# Altium schematic sheet PWM_Pump_Led.SchDoc
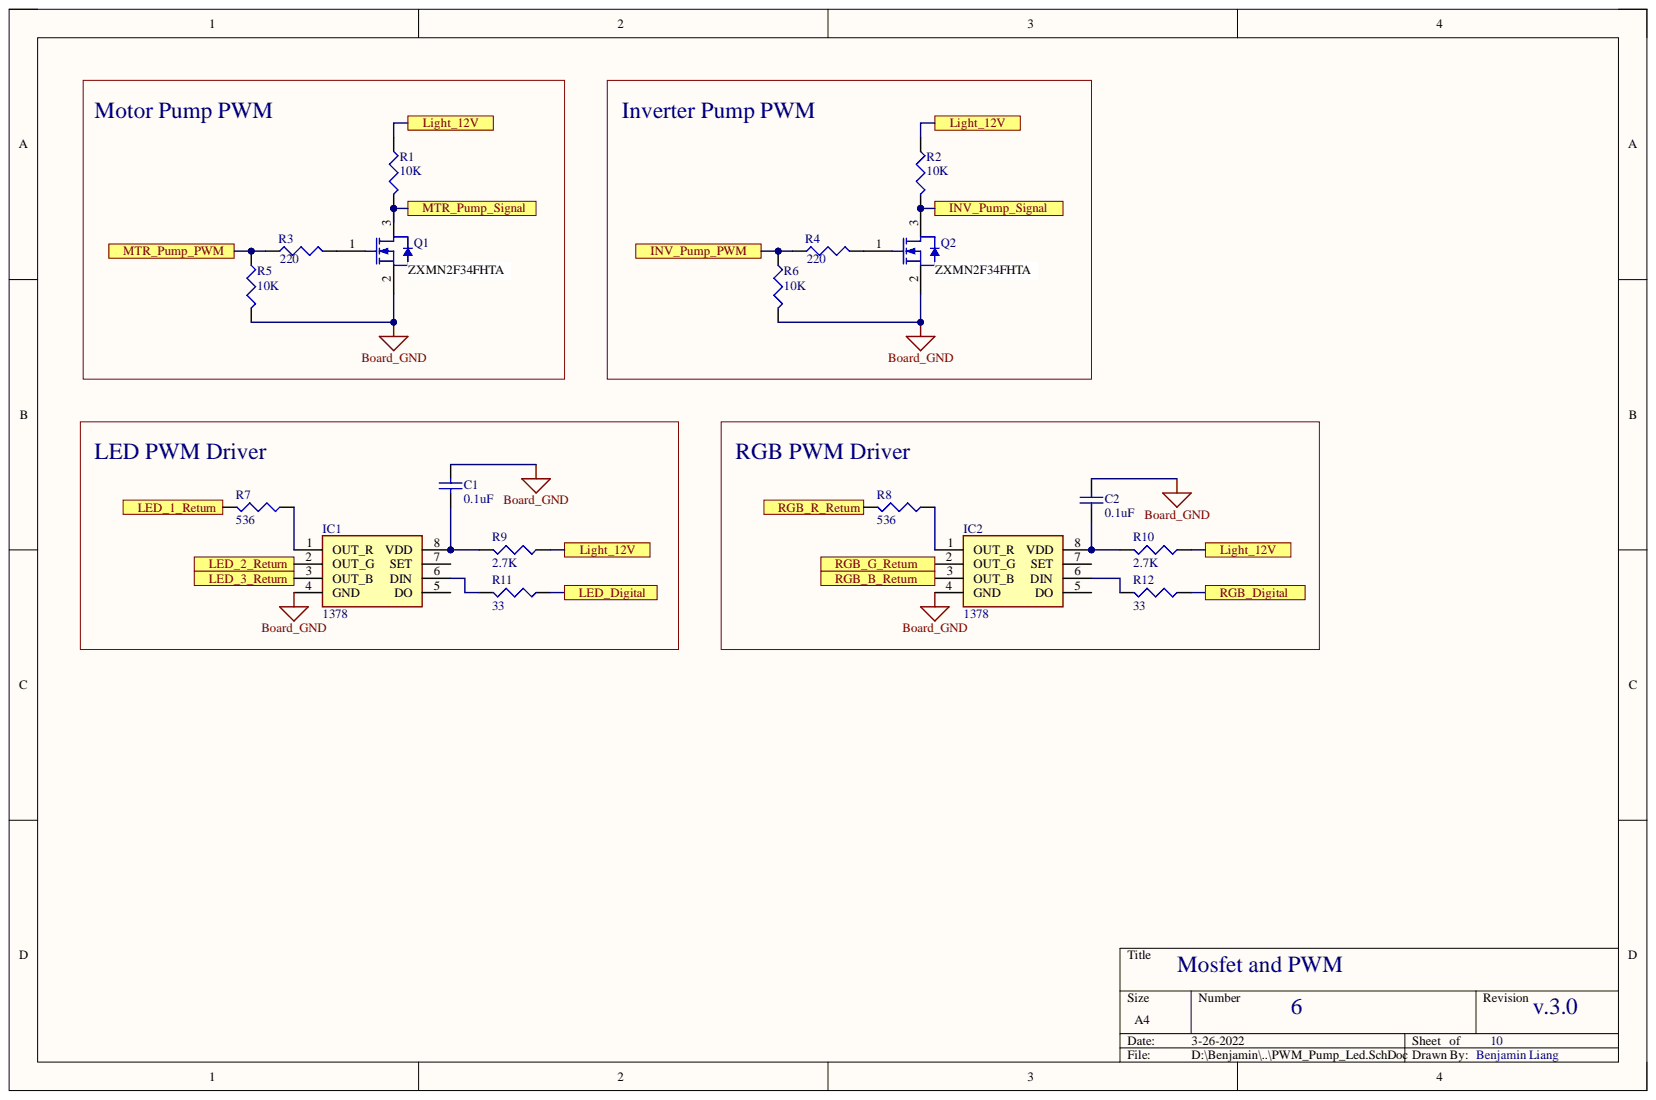
[diagram p0: PWM_Pump_Led.SchDoc]

## Parts
C1  0.1uF  [C1206]
C2  0.1uF  [C1206]
IC1  1378  [DIP762W51P254L920H431Q8N]
IC2  1378  [DIP762W51P254L920H431Q8N]
Q1  ZXMN2F34FHTA  [FAIR-SSOT3L-3_V]
Q2  ZXMN2F34FHTA  [FAIR-SSOT3L-3_V]
R1  10K  [J1-0603]
R2  10K  [J1-0603]
R3  220  [J1-0603]
R4  220  [J1-0603]
R5  10K  [J1-0603]
R6  10K  [J1-0603]
R7  536  [J1-0603]
R8  536  [J1-0603]
R9  2.7K  [J1-0603]
R10  2.7K  [J1-0603]
R11  33  [J1-0603]
R12  33  [J1-0603]

## Ports
INV_Pump_PWM, INV_Pump_Signal, LED_1_Return, LED_2_Return, LED_3_Return, LED_Digital, Light_12V, MTR_Pump_PWM, MTR_Pump_Signal, RGB_B_Return, RGB_Digital, RGB_G_Return, RGB_R_Return

## Nets
Board_GND: C1.1 C2.1 IC1.4(GND) IC2.4(GND) Q1.2 Q2.2 R5.2 R6.2
INV_Pump_PWM: R4.1 R6.1 ; port INV_Pump_PWM
INV_Pump_Signal: Q2.3 R2.2 ; port INV_Pump_Signal
LED_1_Return: R7.2 ; port LED_1_Return
LED_2_Return: IC1.2(OUT_G) ; port LED_2_Return
LED_3_Return: IC1.3(OUT_B) ; port LED_3_Return
LED_Digital: R11.1 ; port LED_Digital
Light_12V: R1.1 R2.1 R9.1 R10.1 ; port Light_12V
MTR_Pump_PWM: R3.1 R5.1 ; port MTR_Pump_PWM
MTR_Pump_Signal: Q1.3 R1.2 ; port MTR_Pump_Signal
RGB_B_Return: IC2.3(OUT_B) ; port RGB_B_Return
RGB_Digital: R12.1 ; port RGB_Digital
RGB_G_Return: IC2.2(OUT_G) ; port RGB_G_Return
RGB_R_Return: R8.2 ; port RGB_R_Return
NetC1_2: C1.2 IC1.8(VDD) R9.2
NetC2_2: C2.2 IC2.8(VDD) R10.2
NetIC1_1: IC1.1(OUT_R) R7.1
NetIC1_6: IC1.6(DIN) R11.2
NetIC2_1: IC2.1(OUT_R) R8.1
NetIC2_6: IC2.6(DIN) R12.2
NetQ1_1: Q1.1 R3.2
NetQ2_1: Q2.1 R4.2

Not connected: IC1 5(DO) 7(SET); IC2 5(DO) 7(SET)

## Library data of the parts
C1 C2 = Cap Semi: Capacitor (Semiconductor SIM Model); Value: 100nF; Component Kind: Standard; LatestRevisionDate: 29-May-2009; LatestRevisionNote: IPC-7351 Footprint Added.; Library Name: Miscellaneous Devices.IntLib; PackageDescription: Chip Capacitor; PackageReference: C1206; Published: 8-Jun-2000; Signal Integrity: Cap Semi; Simulation: CAP; Publisher: Altium Limited
IC1 IC2 = 1378: Integrated Circuit; Manufacturer_Name: Adafruit; Arrow Part Number: 1378; Arrow Price/Stock: https://www.arrow.com/en/products/1378/adafruit-industries; Component Kind: Standard; Datasheet Link: https://componentsearchengine.com/Datasheets/2/1378.pdf; Height: 4.31mm; Manufacturer_Part_Number: 1378; Mouser Part Number: 485-1378; Mouser Price/Stock: https://www.mouser.co.uk/ProductDetail/Adafruit/1378?qs=GURawfaeGuC97B4u3AEc0g%3D%3D
Q1 Q2 = CMP-2000-06249-1: Manufacturer: FairchildSemiconductor; Channel Mode: Enhancement; Channel Type: N; Maximum Continuous Drain Current: 1.7A; Maximum Drain Source Voltage: 20V; Maximum Gate Source Voltage: ±8V; Package Version: Rev. 3, 05/2015; Rad Hard: No; RDS-on: 0.07@4.5VOhm; Typical Fall Time: 3ns; Typical Rise Time: 8.5ns; Typical Turn-Off Delay Time: 11ns; Typical Turn-On Delay Time: 5ns; Category: MOSFET; Component Kind: Standard; Datasheet URL: https://www.fairchildsemi.com/datasheets/FD/FDN335N.pdf; Datasheet Version: Rev. C, 04/1999; Description: ZXMN2F34FHTA; Library Name: Altium Content Vault; Manufacturer URL: https://www.fairchildsemi.com/; Mounting: SurfaceMount; Mounting Technology: SurfaceMount; Operating Temperature: -55to150°C; Package: 3SuperSOT; Package Description: 3-Pin Small Outline Transistor, Body 2.92 x 1.4 mm, Pitch 0.95 mm; Package Reference: SSOT3L; Package URL: https://www.fairchildsemi.com/package-drawings/MA/MA03B.pdf; Packaging: Tape&Reel
R1 R2 R5 R6 = Res3: Resistor; Component Kind: Standard; LatestRevisionDate: 29-May-2009; LatestRevisionNote: IPC-7351 Footprint Added.; Library Name: Miscellaneous Devices.IntLib; PackageDescription: Chip Resistor; PackageReference: J1-0603; Published: 8-Jun-2000; Signal Integrity: Res; Simulation: RESISTOR; Value: 10K; Publisher: Altium Limited
R3 R4 R7-R12 = Res3: Resistor; Value: 10K; Component Kind: Standard; LatestRevisionDate: 29-May-2009; LatestRevisionNote: IPC-7351 Footprint Added.; Library Name: Miscellaneous Devices.IntLib; PackageDescription: Chip Resistor; PackageReference: J1-0603; Published: 8-Jun-2000; Signal Integrity: Res; Simulation: RESISTOR; Publisher: Altium Limited
